# Altium schematic sheet Sensors.SchDoc
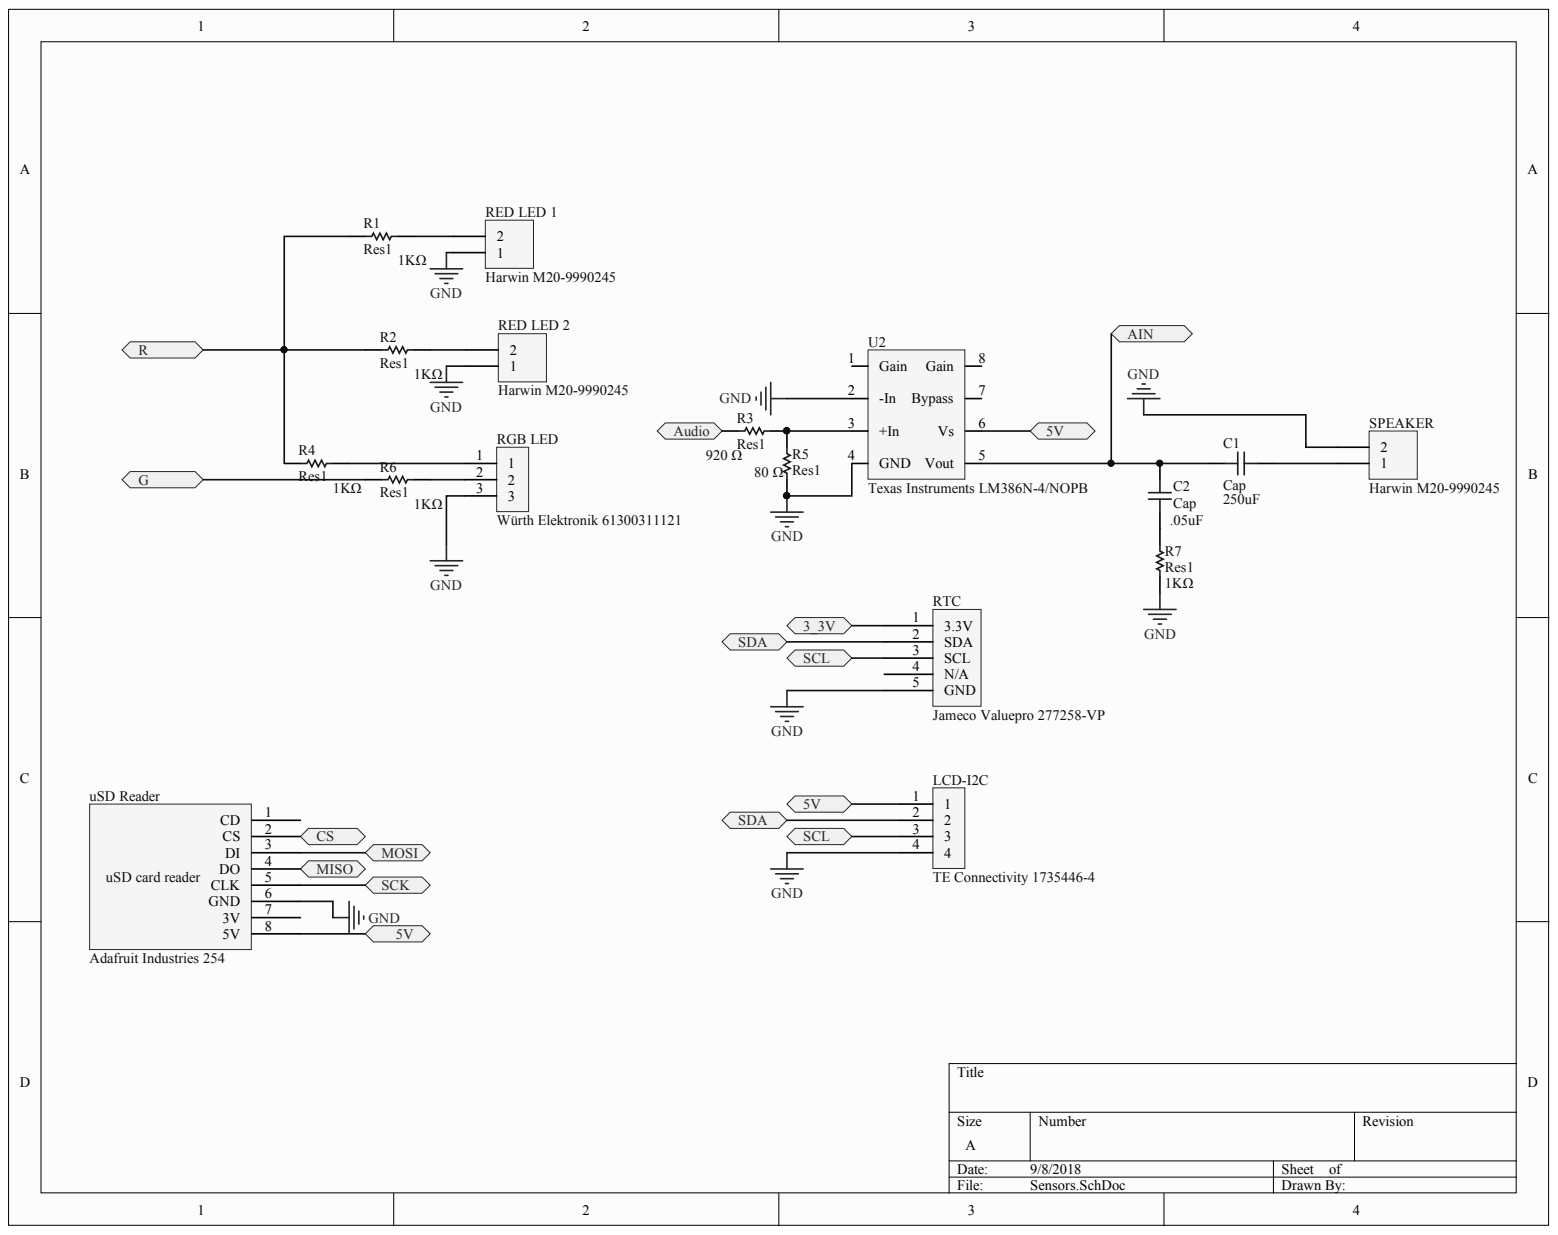
[diagram p0: Sensors.SchDoc]

## Parts
C1  Cap  [PCB-0e55m2lowdvgwfa8nbdo-1]
C2  Cap  Capacitance: .05uF  [PCB-0e55m2lowdvgwfa8nbdo-1]
LCD-I2C  TE Connectivity 1735446-4  [PCB-sbdj0uphkrlcn6lphm0j-1]
R1  Res1  Resistance: 1KΩ  [PCB-rlgmvw1lh4384i09on8f-1]
R2  Res1  Resistance: 1KΩ  [PCB-rlgmvw1lh4384i09on8f-1]
R3  Res1  Resistance: 920 Ω  [PCB-rlgmvw1lh4384i09on8f-1]
R4  Res1  [PCB-rlgmvw1lh4384i09on8f-1]
R5  Res1  Resistance: 80 Ω  [PCB-rlgmvw1lh4384i09on8f-1]
R6  Res1  Resistance: 1KΩ  [PCB-rlgmvw1lh4384i09on8f-1]
R7  Res1  Resistance: 1KΩ  [PCB-rlgmvw1lh4384i09on8f-1]
RED_LED_1  Harwin M20-9990245  Manufacturer Part Number: M20-9990245  [PCB-exg861sgm8y1qikelj7a-1]
RED_LED_2  Harwin M20-9990245  Manufacturer Part Number: M20-9990245  [PCB-exg861sgm8y1qikelj7a-1]
RGB_LED  Würth Elektronik 61300311121  [PCB-r8rj59shvr39751q948r-1]
RTC  Jameco Valuepro 277258-VP  [PCB-95xmlkdj5amoxxed06xg-1]
SPEAKER  Harwin M20-9990245  Manufacturer Part Number: M20-9990245  [PCB-exg861sgm8y1qikelj7a-1]
U2  Texas Instruments LM386N-4/NOPB  [PCB-uplotgaav8npl92xikvu-1]
uSD_Reader  Adafruit Industries 254  [PCB-14uusre4tcuerloricup-1]

## Ports
3_3V, 5V, AIN, AUDIO, CS, G, MISO, MOSI, R, SCK, SCL, SDA

## Nets
GND: LCD-I2C.4 R5.1 R7.1 RED_LED_1.1 RED_LED_2.1 RGB_LED.3 RTC.5(GND) SPEAKER.2 U2.2(-In) U2.4(GND) uSD_Reader.6(GND)
3_3V: RTC.1(3.3V) ; port 3_3V
5V: LCD-I2C.1 U2.6(Vs) uSD_Reader.8(5V) ; port 5V
AIN: C1.1 C2.2 U2.5(Vout) ; port AIN
CS: uSD_Reader.2(CS) ; port CS
G: R6.1 ; port G
MISO: uSD_Reader.4(DO) ; port MISO
MOSI: uSD_Reader.3(DI) ; port MOSI
R: R1.1 R2.1 R4.1 ; port R
SCK: uSD_Reader.5(CLK) ; port SCK
SCL: LCD-I2C.3 RTC.3(SCL) ; port SCL
SDA: LCD-I2C.2 RTC.2(SDA) ; port SDA
NetC1_2: C1.2 SPEAKER.1
NetC2_1: C2.1 R7.2
NetR1_2: R1.2 RED_LED_1.2
NetR2_2: R2.2 RED_LED_2.2
NetR3_1: R3.1 ; port AUDIO
NetR3_2: R3.2 R5.2 U2.3(+In)
NetR4_2: R4.2 RGB_LED.1
NetR6_2: R6.2 RGB_LED.2

Not connected: RTC 4(N/A); U2 1(Gain) 7(Bypass) 8(Gain); uSD_Reader 1(CD) 7(3V)

## Library data of the parts
C1 = CMP-9ca8572543d8436f-1: Cap Ceramic 0.001uF 100V Y5P 10% (5 X 4mm) Radial 5mm 85°C Bulk; Manufacturer Part Number: D102K20Y5PH63J5R; Capacitance: 250uF; Capacitance Tolerance: ±10%; Component Kind: Standard; Dielectric Characteristic: Y5P; Library Name: CommunityVault; Mounting Style: Through Hole; Number of Pins: 2; OctopartID: 9ca8572543d8436f; Octopart URL: https://octopart.com/d102k20y5ph63j5r-vishay-42465814; Operating Temperature: -30.0 °C (min); Packaging: Bulk; RoHS: Compliant
C2 = CMP-9ca8572543d8436f-1: Cap Ceramic 0.001uF 100V Y5P 10% (5 X 4mm) Radial 5mm 85°C Bulk; Manufacturer Part Number: D102K20Y5PH63J5R; Capacitance Tolerance: ±10%; Component Kind: Standard; Dielectric Characteristic: Y5P; Library Name: CommunityVault; Mounting Style: Through Hole; Number of Pins: 2; OctopartID: 9ca8572543d8436f; Octopart URL: https://octopart.com/d102k20y5ph63j5r-vishay-42465814; Operating Temperature: -30.0 °C (min); Packaging: Bulk; RoHS: Compliant
LCD-I2C = CMP-07c0cc47a123d807-4: HPI Series, 2mm Pitch 4 Way 1 Row Straight PCB Header, Solder Termination; Component Kind: Standard; Library Name: CommunityVault
R1-R3 R5-R7 = CMP-43cd8ac541879c0a-1: Axial Lead res; Power Rating: 5.00 W; Resistance Tolerance: ±1%; Component Kind: Standard; Library Name: CommunityVault; Mounting Style: Through Hole, Axial; OctopartID: 43cd8ac541879c0a; RoHS: Compliant; Size-Diameter: 8.71 mm; Size-Length: 23.8 mm
R4 = CMP-43cd8ac541879c0a-1: Axial Lead res; Power Rating: 5.00 W; Resistance: 1KΩ; Resistance Tolerance: ±1%; Component Kind: Standard; Library Name: CommunityVault; Mounting Style: Through Hole, Axial; OctopartID: 43cd8ac541879c0a; RoHS: Compliant; Size-Diameter: 8.71 mm; Size-Length: 23.8 mm
RED_LED_1 RED_LED_2 SPEAKER = CMP-2482229-22: Board-To-Board Connector, M20 Series, Through Hole, Header, 2, 2.54 mm, Gold Plated Contacts; Contact Resistance: 25.0 mΩ; Number of Mating Cycles: 300; Voltage Rating (AC): 750 V; Component Kind: Standard; Library Name: CommunityVault; OctopartID: 13d0daa8641bcc17; Operating Temperature: -40.0 °C (min)
RGB_LED = CMP-8a90426ffa175557-16: Board-To-Board Connector, Vertical, Wr-Phd Series, Through Hole, Header, 3, 2.54 Mm; Component Kind: Standard; Library Name: CommunityVault; OctopartID: 8a90426ffa175557
RTC = CMP-4f86825e30559041-1: DS3231 RTC Real Time Clock Module - Super Capacitor; Component Kind: Standard; Library Name: FavoritesLibrary; Manufacturer Part Number: 277258-VP; OctopartID: 4f86825e30559041; Octopart URL: https://octopart.com/277258-vp-jameco+valuepro-80894645
U2 = CMP-1152321-3: Linear IC - Audio amplifier Texas Instruments LM386N-4/NOPB 1-channel (mono) Class AB PDIP 8; Gain: 20.0 dB; Output Power: 1.00 W; Supply Voltage (DC): 6.00 V, 15.0 V (max); Component Kind: Standard; Library Name: CommunityVault; Packaging: Rail
uSD_Reader = CMP-d7261fdbc47208e1-11: Roll Pin Punch 5/32 x 4-1/2 Inch Steel - GR0191303; Manufacturer Part Number: 254; Component Kind: Standard; Library Name: CommunityVault; OctopartID: d7261fdbc47208e1; Simulation: SIM-djxxftjbxr24j5ekzlqf-1
